# Altium schematic sheet S7CAS-PLEIADES-CARTE-MERE_P07_LOW_POWER.SchDoc(S7CAS-PLEIADES-CARTE-MERE_P07_LOW_POWER)
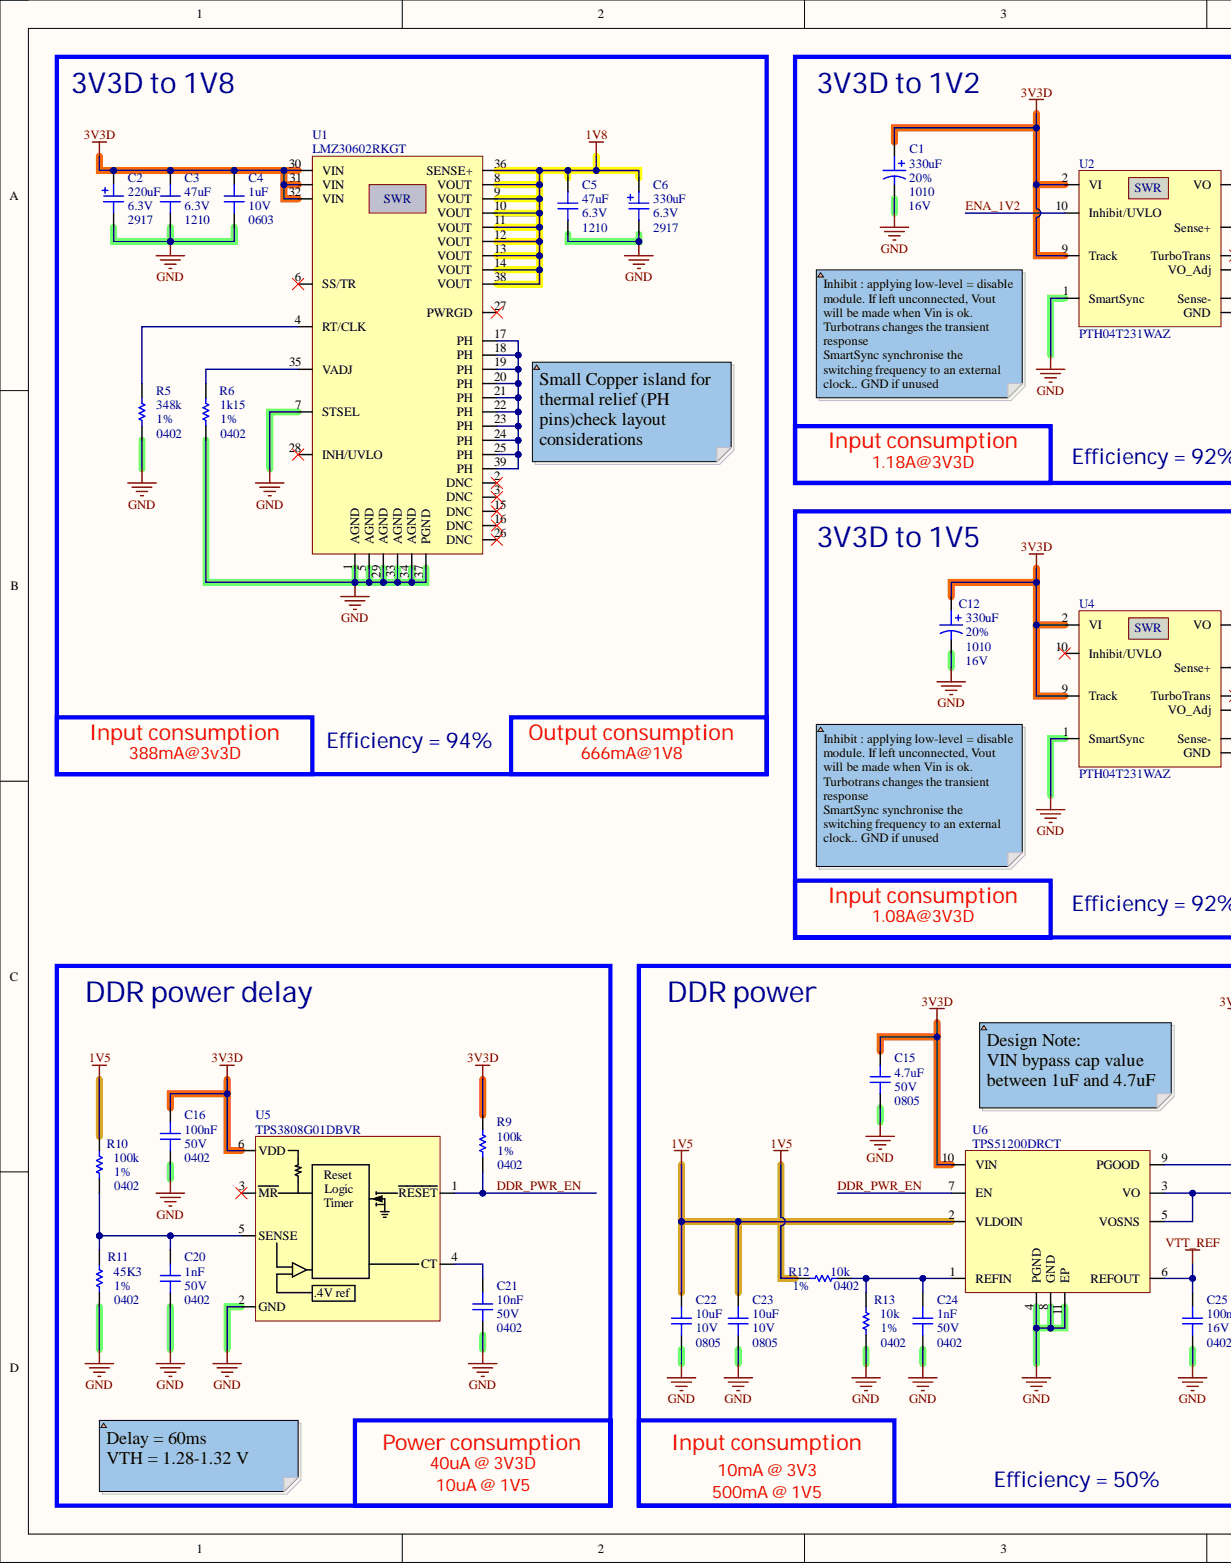
[diagram p0: S7CAS-PLEIADES-CARTE-MERE_P07_LOW_POWER.SchDoc, left]
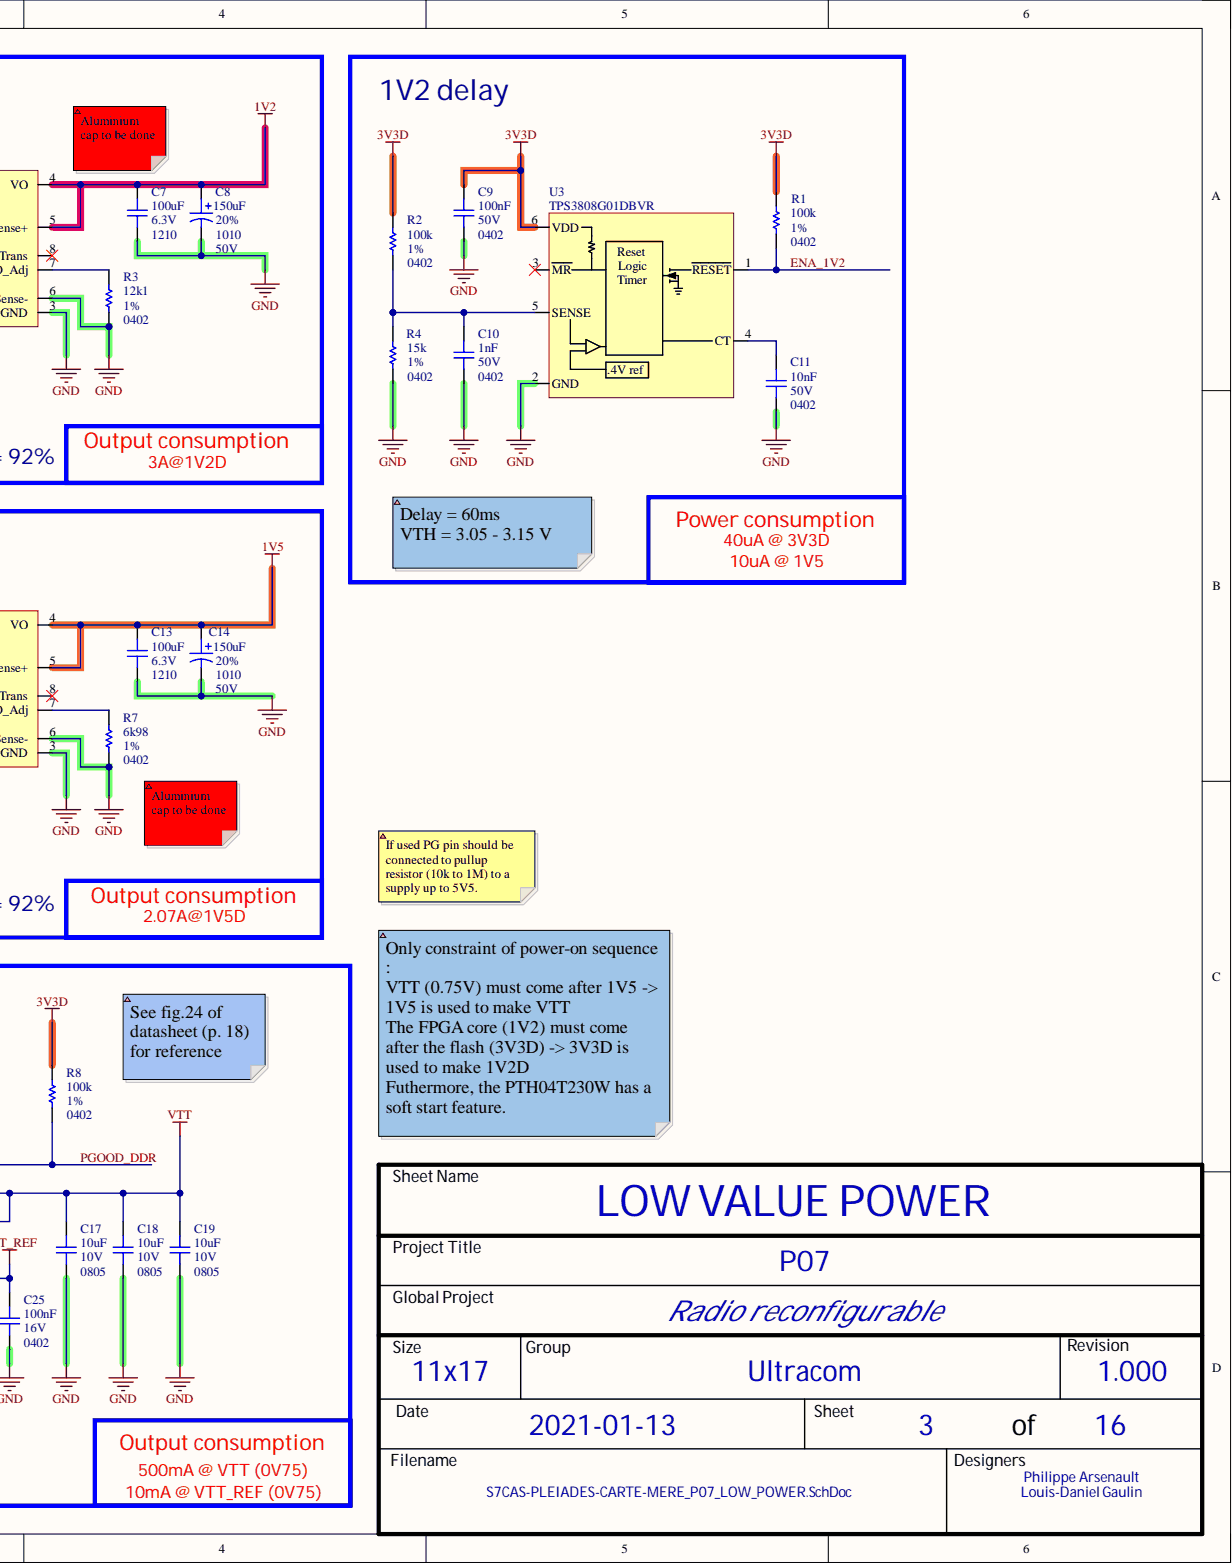
[diagram p1: S7CAS-PLEIADES-CARTE-MERE_P07_LOW_POWER.SchDoc, right]

## Parts
C1  value 330uF  Package: 1010  Precision: 20%  Voltage: 16V  [CAP_ALU_1010_vishay]  (hidden comment: MAL214699501E3)
C2  value 220uF  Package: 2917  Voltage: 6.3V  [CAP_TAN_2917(D)]  (hidden comment: CAP - TANTALUM - CASE D)
C3  value 47uF  Package: 1210  Voltage: 6.3V  [CAP_1210]  (hidden comment: CAP - 1210)
C4  value 1uF  Package: 0603  Voltage: 10V  [CAP_0603]  (hidden comment: CAP - 0603)
C5  value 47uF  Package: 1210  Voltage: 6.3V  [CAP_1210]  (hidden comment: CAP - 1210)
C6  value 330uF  Package: 2917  Voltage: 6.3V  [CAP_TAN_2917(D)]  (hidden comment: CAP - TANTALUM - CASE D)
C7  value 100uF  Package: 1210  Voltage: 6.3V  [CAP_1210]  (hidden comment: CAP - 1210)
C8  value 150uF  Package: 1010  Precision: 20%  Voltage: 50V  [CAP_ALU_1010_vishay]  (hidden comment: MAL215099101E3)
C9  value 100nF  Package: 0402  Voltage: 50V  [CAP_0402]  (hidden comment: CAP - 0402)
C10  value 1nF  Package: 0402  Voltage: 50V  [CAP_0402]  (hidden comment: CAP - 0402)
C11  value 10nF  Package: 0402  Voltage: 50V  [CAP_0402]  (hidden comment: CAP - 0402)
C12  value 330uF  Package: 1010  Precision: 20%  Voltage: 16V  [CAP_ALU_1010_vishay]  (hidden comment: MAL214699501E3)
C13  value 100uF  Package: 1210  Voltage: 6.3V  [CAP_1210]  (hidden comment: CAP - 1210)
C14  value 150uF  Package: 1010  Precision: 20%  Voltage: 50V  [CAP_ALU_1010_vishay]  (hidden comment: MAL215099101E3)
C15  value 4.7uF  Package: 0805  Voltage: 50V  [CAP_0805]  (hidden comment: CAP - 0805)
C16  value 100nF  Package: 0402  Voltage: 50V  [CAP_0402]  (hidden comment: CAP - 0402)
C17  value 10uF  Package: 0805  Voltage: 10V  [CAP_0805]  (hidden comment: CAP - 0805)
C18  value 10uF  Package: 0805  Voltage: 10V  [CAP_0805]  (hidden comment: CAP - 0805)
C19  value 10uF  Package: 0805  Voltage: 10V  [CAP_0805]  (hidden comment: CAP - 0805)
C20  value 1nF  Package: 0402  Voltage: 50V  [CAP_0402]  (hidden comment: CAP - 0402)
C21  value 10nF  Package: 0402  Voltage: 50V  [CAP_0402]  (hidden comment: CAP - 0402)
C22  value 10uF  Package: 0805  Voltage: 10V  [CAP_0805]  (hidden comment: CAP - 0805)
C23  value 10uF  Package: 0805  Voltage: 10V  [CAP_0805]  (hidden comment: CAP - 0805)
C24  value 1nF  Package: 0402  Voltage: 50V  [CAP_0402]  (hidden comment: CAP - 0402)
C25  value 100nF  Package: 0402  Voltage: 16V  [CAP_0402]  (hidden comment: CAP - 0402)
R1  value 100k  Package: 0402  Precision: 1%  [RES_0402]  (hidden comment: RES - 0402)
R2  value 100k  Package: 0402  Precision: 1%  [RES_0402]  (hidden comment: RES - 0402)
R3  value 12k1  Package: 0402  Precision: 1%  [RES_0402]  (hidden comment: RES - 0402)
R4  value 15k  Package: 0402  Precision: 1%  [RES_0402]  (hidden comment: RES - 0402)
R5  value 348k  Package: 0402  Precision: 1%  [RES_0402]  (hidden comment: RES - 0402)
R6  value 1k15  Package: 0402  Precision: 1%  [RES_0402]  (hidden comment: RES - 0402)
R7  value 6k98  Package: 0402  Precision: 1%  [RES_0402]  (hidden comment: RES - 0402)
R8  value 100k  Package: 0402  Precision: 1%  [RES_0402]  (hidden comment: RES - 0402)
R9  value 100k  Package: 0402  Precision: 1%  [RES_0402]  (hidden comment: RES - 0402)
R10  value 100k  Package: 0402  Precision: 1%  [RES_0402]  (hidden comment: RES - 0402)
R11  value 45K3  Package: 0402  Precision: 1%  [RES_0402]  (hidden comment: RES - 0402)
R12  value 10k  Package: 0402  Precision: 1%  [RES_0402]  (hidden comment: RES - 0402)
R13  value 10k  Package: 0402  Precision: 1%  [RES_0402]  (hidden comment: RES - 0402)
U1  LMZ30602RKGT  [REG_SWI_LMZ30606RKGT]
U2  PTH04T231WAZ  [REG_SWR_PTH04T231WAZ]
U3  TPS3808G01DBVR  [POW_TPS3808G01DBVR]
U4  PTH04T231WAZ  [REG_SWR_PTH04T231WAZ]
U5  TPS3808G01DBVR  [POW_TPS3808G01DBVR]
U6  TPS51200DRCT  [REG_PMIC_TPS51200DRCT]

## Nets
1V2: C7.2 C8.1 U2.4(VO) U2.5(Sense+)
1V5: C13.2 C14.1 C22.2 C23.2 R10.2 R12.2 U4.4(VO) U4.5(Sense+) U6.2(VLDOIN)
1V8: C5.2 C6.2 U1.8(VOUT) U1.9(VOUT) U1.10(VOUT) U1.11(VOUT) U1.12(VOUT) U1.13(VOUT) U1.14(VOUT) U1.36(SENSE+) U1.38(VOUT)
3V3D: C1.1 C2.2 C3.2 C4.2 C9.2 C12.1 C15.2 C16.2 R1.2 R2.2 R8.2 R9.2 U1.30(VIN) U1.31(VIN) U1.32(VIN) U2.2(VI) U2.9(Track) U3.6(VDD) U4.2(VI) U4.9(Track) U5.6(VDD) U6.10(VIN)
GND: C1.2 C2.1 C3.1 C4.1 C5.1 C6.1 C7.1 C8.2 C9.1 C10.1 C11.1 C12.2 C13.1 C14.2 C15.1 C16.1 C17.1 C18.1 C19.1 C20.1 C21.1 C22.1 C23.1 C24.1 C25.1 R3.1 R4.1 R5.1 R6.1 R7.1 R11.1 R13.1 U1.1(AGND) U1.5(AGND) U1.7(STSEL) U1.29(AGND) U1.33(AGND) U1.34(AGND) U1.37(PGND) U2.1(SmartSync) U2.3(GND) U2.6(Sense-) U3.2(GND) U4.1(SmartSync) U4.3(GND) U4.6(Sense-) U5.2(GND) U6.4(PGND) U6.8(GND) U6.11(EP)
VTT: C17.2 C18.2 C19.2 U6.3(VO) U6.5(VOSNS)
VTT_REF: C25.2 U6.6(REFOUT)
DDR_PWR_EN: R9.1 U5.1(RESET) U6.7(EN)
ENA_1V2: R1.1 U2.10(Inhibit/UVLO) U3.1(RESET)
PGOOD_DDR: R8.1 U6.9(PGOOD)
NetC10_2: C10.2 R2.1 R4.2 U3.5(SENSE)
NetC11_2: C11.2 U3.4(CT)
NetC20_2: C20.2 R10.1 R11.2 U5.5(SENSE)
NetC21_2: C21.2 U5.4(CT)
NetC24_2: C24.2 R12.1 R13.2 U6.1(REFIN)
NetR3_2: R3.2 U2.7(VO_Adj)
NetR5_2: R5.2 U1.4(RT/CLK)
NetR6_2: R6.2 U1.35(VADJ)
NetR7_2: R7.2 U4.7(VO_Adj)
NetU1_17: U1.17(PH) U1.18(PH) U1.19(PH) U1.20(PH) U1.21(PH) U1.22(PH) U1.23(PH) U1.24(PH) U1.25(PH) U1.39(PH)

Not connected: U1 2(DNC) 3(DNC) 6(SS/TR) 15(DNC) 16(DNC) 26(DNC) 27(PWRGD) 28(INH/UVLO); U2 8(TurboTrans); U3 3(MR); U4 8(TurboTrans) 10(Inhibit/UVLO); U5 3(MR)

## Library data of the parts
C1 C12 = CAP_ALU_MAL214699501E3: CAP - ALU 330uF 20% 16V - 1010; Current: 750mA; Mfg: Vishay Dale; Component Kind: Standard; HelpURL: http://www.vishay.com/docs/28403/146cti.pdf; Library Name: S7CAS_LIB2021.IntLib; Mfg Part Number: MAL214699501E3; Supplier 1: Digikey; Supplier Part Number 1: 4405PHCT-ND; Temperature: -55°C to 125°C; CreatedBy: Jonathan Bouchard
C2 C6 = CAP_TANT_2917(D): CAP - TANTALUM - 2917; Precision: 10%; Component Kind: Standard; Library Name: S7CAS_LIB2021.IntLib; CreatedBy: Jonathan Bouchard
C3 C5 C7 C13 = CAP - 1210: Precision: 10%; Component Kind: Standard; Description: CAP - 1210; Library Name: S7CAS_LIB2021.IntLib; CreatedBy: Jonathan Bouchard
C4 = CAP - 0603: Precision: 10%; Component Kind: Standard; Description: CAP - 0603; Library Name: S7CAS_LIB2021.IntLib; CreatedBy: Jonathan Bouchard
C8 C14 = CAP_ALU_MAL215099101E3: CAP - ALU 100uF 20% 50V - 1010; Current: 490mA; Mfg: Vishay Dale; Component Kind: Standard; HelpURL: http://www.vishay.com/docs/28395/150crz.pdf; Library Name: S7CAS_LIB2021.IntLib; Mfg Part Number: MAL215099101E3; Supplier 1: Digikey; Supplier Part Number 1: 4399PHDKR-ND; Temperature: -55°C to 125°C; CreatedBy: Jonathan Bouchard
C9 C10 C16 C20 C24 = CAP - 0402: Mfg: C1005X7R1H102K050BA; Precision: 10%; Component Kind: Standard; Description: CAP - 0402; Library Name: S7CAS_LIB2021.IntLib; CreatedBy: Jonathan Bouchard
C11 C21 = CAP - 0402: Precision: 10%; Component Kind: Standard; Description: CAP - 0402; Library Name: S7CAS_LIB2021.IntLib; CreatedBy: Jonathan Bouchard
C15 = CAP - 0805: Precision: 10%; Component Kind: Standard; Description: CAP - 0805; Library Name: S7CAS_LIB2021.IntLib; CreatedBy: Jonathan Bouchard
C17-C19 C22 C23 = CAP - 0805: Mfg: CL21B106KPQNNNE; Precision: 10%; Component Kind: Standard; Description: CAP - 0805; Library Name: S7CAS_LIB2021.IntLib; CreatedBy: Jonathan Bouchard
C25 = CAP - 0402: Mfg Part Number: CL05B104KO5NNNC; Precision: 10%; Component Kind: Standard; Description: CAP - 0402; Library Name: S7CAS_LIB2021.IntLib; CreatedBy: Jonathan Bouchard
R1-R13 = RES - 0402: Power: 1/16W; Voltage: 50V; Component Kind: Standard; Description: RES - 0402; Library Name: S7CAS_LIB2021.IntLib; CreatedBy: Jonathan Bouchard
U1 = REG_SWR_LMZ30602RKGT: REG - DC DC Converter    Non-Isolated  Output 0.8 ~ 3.6V 2A 2.95V - 6V Input; Manufacturer #1: Texas Instruments; Cryo: UNKNOWN; GRAMS Lib Spec: 2.8c; Polarized: Yes; Power Max: 7W; Value: 0.8-3.6V; Voltage Rating: 2.95-6V; Assembly Type: SMD; Component Kind: Standard; Current Rating: 2A; HelpURL: https://www.ti.com/lit/ds/symlink/lmz30602.pdf?ts=1612193535337&ref_url=https%253A%252F%252Fwww.ti.com%252Fproduct%252FLMZ30602%253Futm_source%253Dgoogle%2526utm_medium%253Dcpc%2526utm_campaign%253Dapp-null-null-GPN_EN-cpc-pf-google-wwe%2526utm_content%253DLMZ30602%2526ds_k%253DLMZ30602%2526DCM%253Dyes%2526gclid%253DCj0KCQiA6t6ABhDMARIsAONIYywbX5HTDjPcy63-ILCw60ouTSqFGPALMyLaft3c1Oc_UEXWX4eCDSkaAiF9EALw_wcB%2526gclsrc%253Daw.ds; Library Name: S7CAS_P07.SchLib; Magnetic Material: Unknown; Package: 39-BQFN Module; Part Number #1: LMZ30602RKGT; Supplier 1: Digi-Key; Supplier Part Number 1: 296-37029-1-ND; Temperature: -40°C ~ 85°C; CreatedBy/Date: Philippe Arsenault/2021-02-01
U2 U4 = REG_SWR_PTH04T231WAZ: REG - MODULE PIP 6A 2.2V/5.5V - 10SMD; Manufacturer #1: Texas Instruments; Cryo: UNKNOWN; Current Rating: 6A (out); Polarized: Yes; Value: 0.69 - 3.6V (Out); Voltage rating: 2.2-5.5V (Input); Assembly Type: SMD; Component Kind: Standard; HelpURL: http://www.ti.com/lit/ds/symlink/pth04t231w.pdf; Library Name: S7CAS_LIB2021.IntLib; Magnetic Material: UNKNOWN; Package: SMD; Part Number #1: PTH04T231WAZ; Supplier 1: Digi-Key; Supplier Part Number 1: 296-21792-ND; Temperature: -40°C ~ 85°C; CreatedBy/Date: Olivier Bernard / 6/5/2018; VerifiedBy: Caroline Paulin / 10 juillet 2018
U3 U5 = POW_TPS3808G01DBVR: POW - Programmable-Delay  Voltage Supervisory adj - SOT-23-6; Manufacturer #1: Texas Instruments; Cryo: UNKNOWN; GRAMS Lib Spec: 2.8; Polarized: Yes; Assembly Type: SMD; Component Kind: Standard; HelpURL: http://www.ti.com/lit/ds/symlink/tps3808.pdf; Library Name: S7CAS_LIB2021.IntLib; Magnetic Material: Unknown; Package: SOT-23-6; Part Number #1: TPS3808G01DBVR; Supplier 1: Digi-Key; Supplier Part Number 1: 296-17188-1-ND; Temperature: -40°C ~ 125°C; CreatedBy/Date: Joel Lemay 2018-11-13; VerifiedBy: Caroline Paulin - 22/11/2018
U6 = REG_PMIC_TPS51200DRCT: REG - DDR TERMINATION/REF REG - 10-VFDFN; Manufacturer #1: Texas Instruments; Cryo: UNKNOWN; Current Rating: Source: 3A~4.5A, Sink: 3.5A~5.5A; GRAMS Lib Spec: 2.8; Polarized: Yes; Value: DDR, DDR2, DDR3(L), DDR4; Voltage Rating: 2.375V ~ 3.5V; Assembly Type: SMD; Component Kind: Standard; HelpURL: http://www.ti.com/lit/ds/symlink/tps51200.pdf; Library Name: S7CAS_LIB2021.IntLib; Magnetic Material: Unknown; Package: 10-VFDFN; Part Number #1: TPS51200DRCT; Supplier 1: Digi-Key; Supplier Part Number 1: 296-23830-1-ND; Temperature: -40°C ~ 85°C; CreatedBy/Date: Joel Lemay 2019-03-19; VerifiedBy: Nicolas V. (2019-05-31)
